# Altium schematic sheet STM32MCUBLUE0.0A.SchDoc(STM32MCUBLUE0.0A)
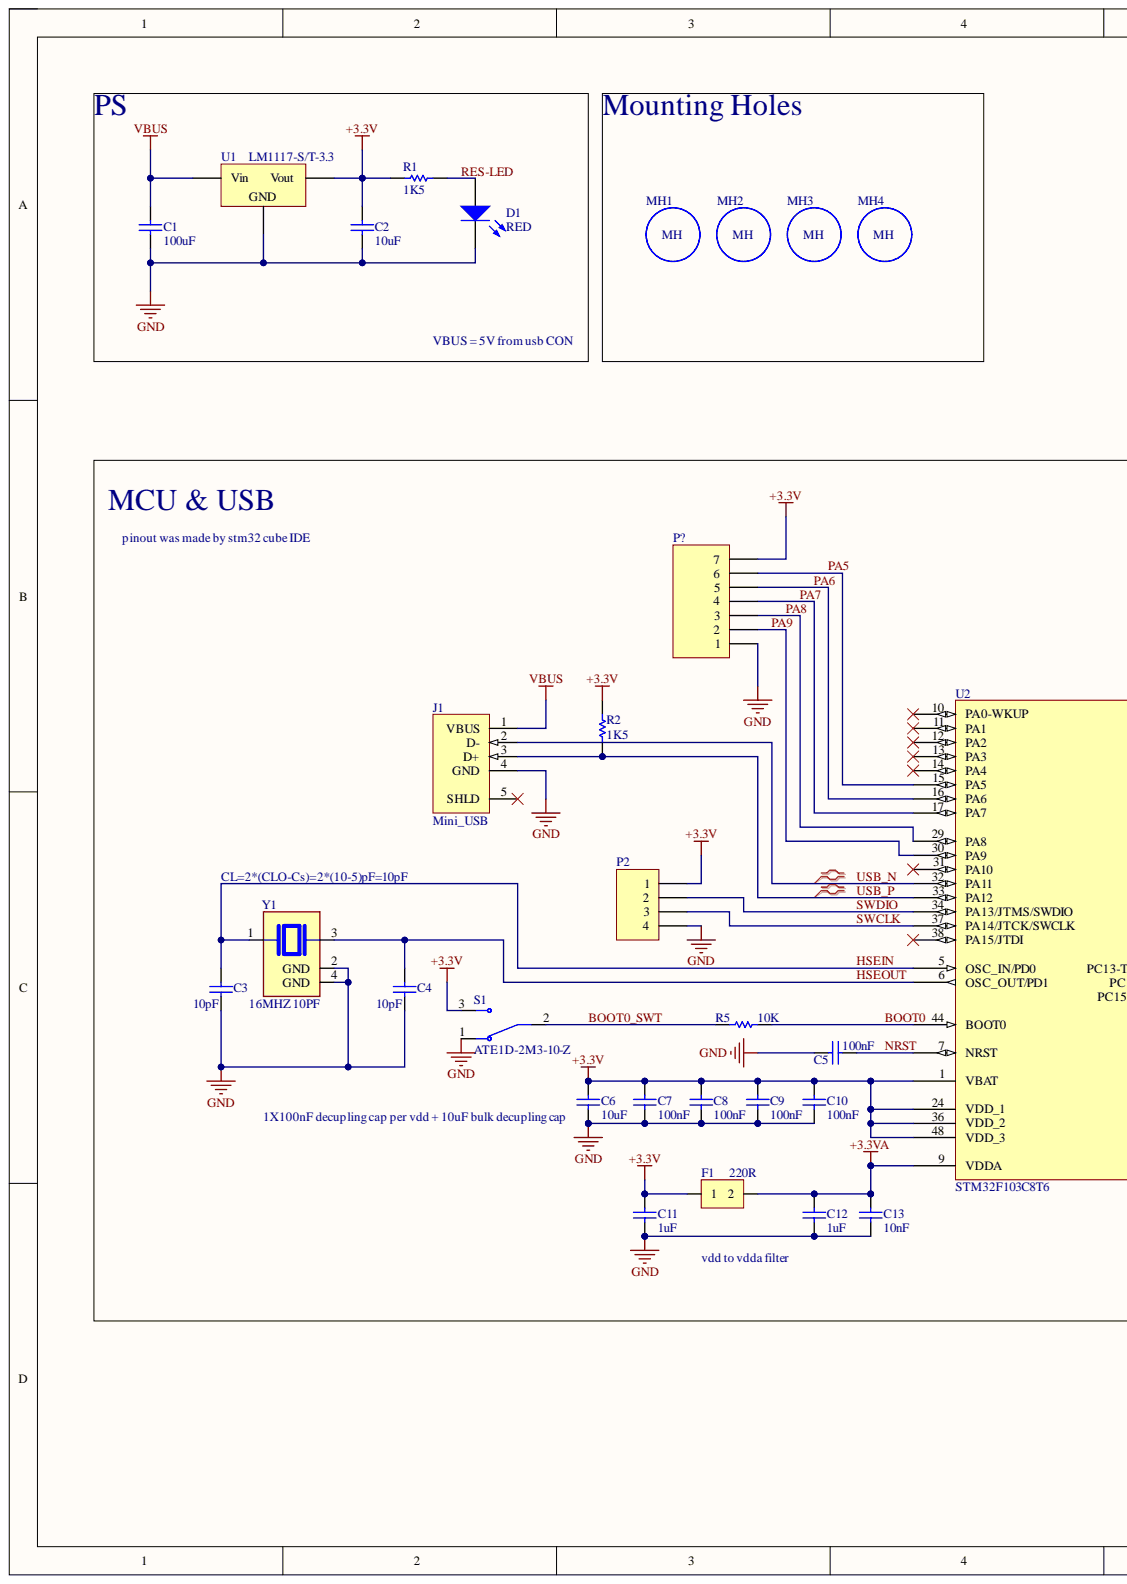
[diagram p0: STM32MCUBLUE0.0A.SchDoc, left]
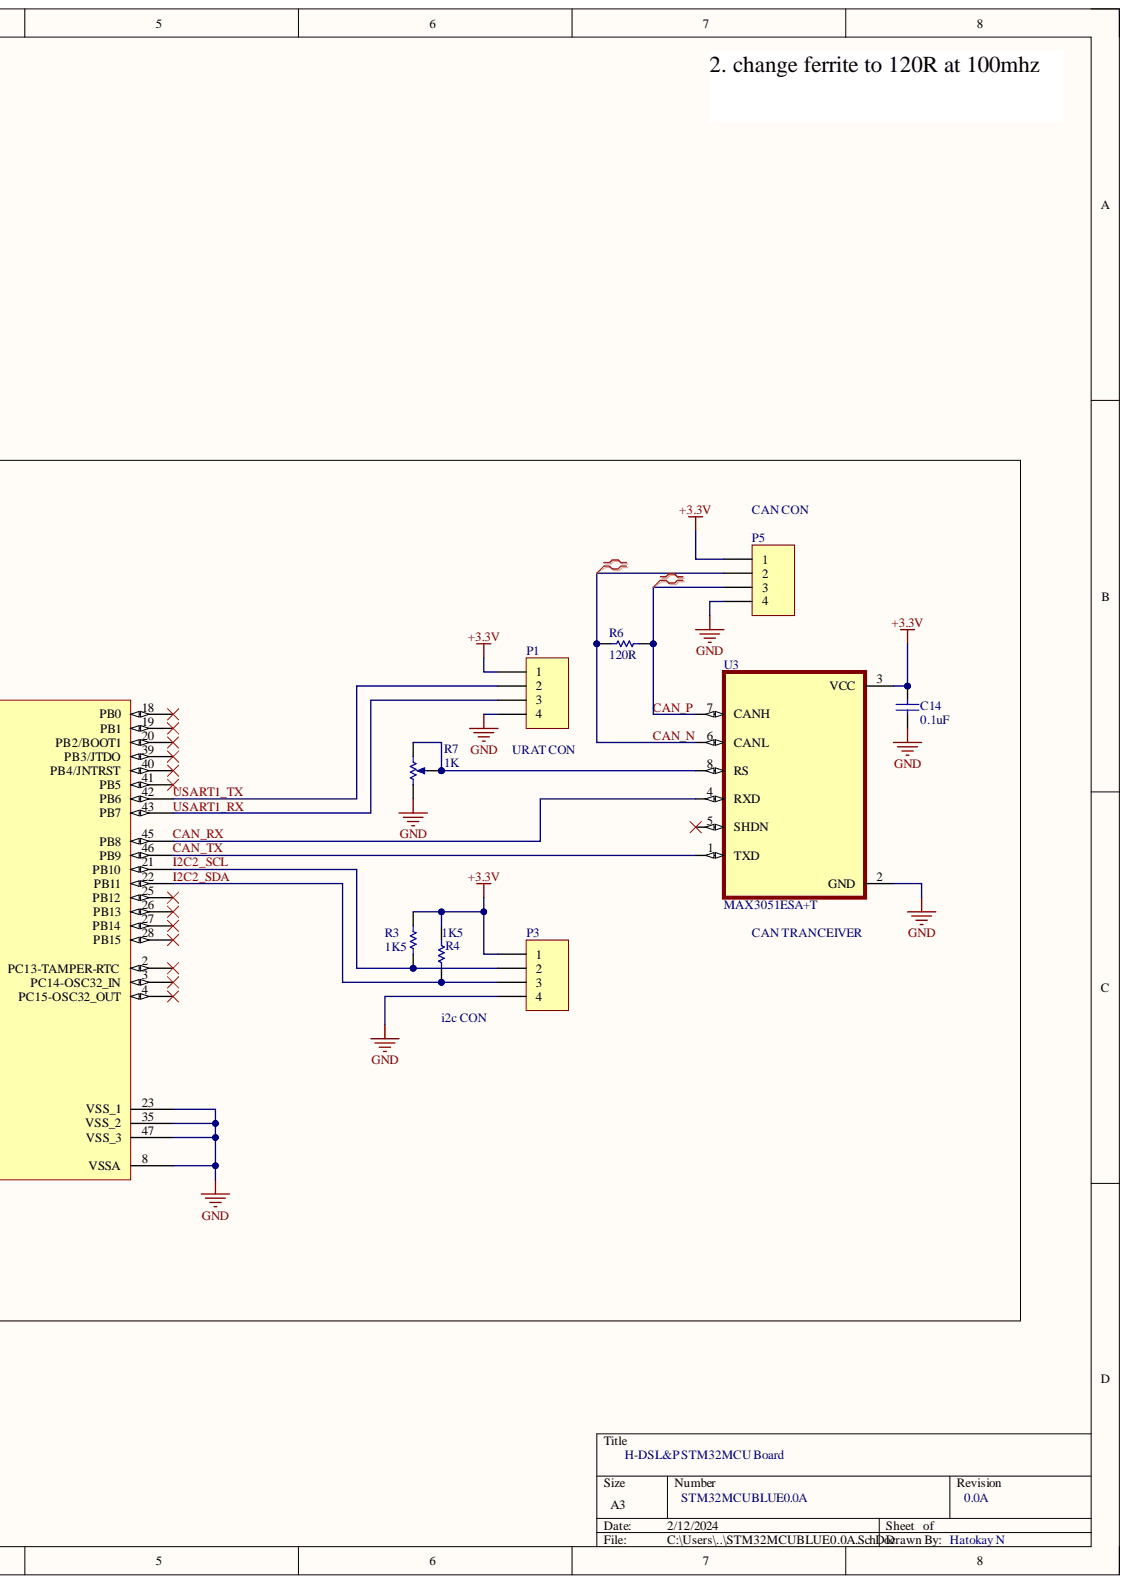
[diagram p1: STM32MCUBLUE0.0A.SchDoc, right]

## Parts
C1  100uF  [MY_0603]
C2  10uF  [MY_0603]
C3  10pF  [MY_0603]
C4  10pF  [MY_0603]
C5  100nF  [MY_0603]
C6  10uF  [MY_0603]
C7  100nF  [MY_0603]
C8  100nF  [MY_0603]
C9  100nF  [MY_0603]
C10  100nF  [MY_0603]
C11  1uF  [MY_0603]
C12  1uF  [MY_0603]
C13  10nF  [MY_0603]
C14  0.1uF  [MY_0603]
D1  RED  [MY_LED_0805]
F1  220R  [0805]
J1  Mini_USB  [My_USB]
MH1  3mm Mounting Hole  [3mm Mouning Hole]  (value not drawn)
MH2  3mm Mounting Hole  [3mm Mouning Hole]  (value not drawn)
MH3  3mm Mounting Hole  [3mm Mouning Hole]  (value not drawn)
MH4  3mm Mounting Hole  [3mm Mouning Hole]  (value not drawn)
P1  Header 4  [My_1X04]  (value not drawn)
P2  Header 4  [My_1X04]  (value not drawn)
P3  Header 4  [My_1X04]  (value not drawn)
P5  Header 4  [My_1X04]  (value not drawn)
P?  Header 7  [MY_FE07]  (value not drawn)
R1  1K5  [MY_0402]
R2  1K5  [MY_0402]
R3  1K5  [MY_0402]
R4  1K5  [MY_0402]
R5  10K  [MY_0402]
R6  120R  [MY_0402]
R7  1K  [My_Pot]
S1  ATE1D-2M3-10-Z  [SW_ATE1D-2M3-10-Z]
U1  LM1117-S/T-3.3  [MY_SOT223]
U2  STM32F103C8T6  [LQFP48_N]
U3  MAX3051ESA+T  [SOIC127P600X175-8N]
Y1  16MHZ 10PF  [XTAL_ABM8-16.000MHZ-10-1-U-T]

## Nets
+3.3V: C2.2 C6.2 C7.2 C8.2 C9.2 C10.2 C11.2 C14.2 F1.1 P1.1 P2.1 P3.1 P5.1 P?.7 R1.2 R2.2 R3.2 R4.2 S1.3 U1.2 U2.1(VBAT) U2.24(VDD_1) U2.36(VDD_2) U2.48(VDD_3) U3.3(VCC)
+3.3VA: C12.2 C13.2 F1.2 U2.9(VDDA)
GND: C1.1 C2.1 C3.1 C4.1 C5.1 C6.1 C7.1 C8.1 C9.1 C10.1 C11.1 C12.1 C13.1 C14.1 D1.K J1.4(GND) P1.4 P2.4 P3.4 P5.4 P?.1 R7.1 S1.1 U1.1 U2.8(VSSA) U2.23(VSS_1) U2.35(VSS_2) U2.47(VSS_3) U3.2(GND) Y1.2(GND) Y1.4(GND)
VBUS: C1.2 J1.1(VBUS) U1.3
BOOT0: R5.2 U2.44(BOOT0)
BOOT0_SWT: R5.1 S1.2
CAN_N: P5.2 R6.2 U3.6(CANL)
CAN_P: P5.3 R6.1 U3.7(CANH)
CAN_RX: U2.45(PB8) U3.4(RXD)
CAN_TX: U2.46(PB9) U3.1(TXD)
HSEIN: C3.2 U2.5(OSC_IN/PD0) Y1.1
HSEOUT: C4.2 U2.6(OSC_OUT/PD1) Y1.3
I2C2_SCL: P3.2 R3.1 U2.21(PB10)
I2C2_SDA: P3.3 R4.1 U2.22(PB11)
NRST: C5.2 U2.7(NRST)
PA5: P?.6 U2.15(PA5)
PA6: P?.5 U2.16(PA6)
PA7: P?.4 U2.17(PA7)
PA8: P?.3 U2.29(PA8)
PA9: P?.2 U2.30(PA9)
RES-LED: D1.A R1.1
SWCLK: P2.3 U2.37(PA14/JTCK/SWCLK)
SWDIO: P2.2 U2.34(PA13/JTMS/SWDIO)
USART1_RX: P1.3 U2.43(PB7)
USART1_TX: P1.2 U2.42(PB6)
USB_N: J1.2(D-) U2.32(PA11)
USB_P: J1.3(D+) R2.1 U2.33(PA12)
NetR7_2: R7.2 R7.3 U3.8(RS)

Not connected: J1 5(SHLD); U2 2(PC13-TAMPER-RTC) 3(PC14-OSC32_IN) 4(PC15-OSC32_OUT) 10(PA0-WKUP) 11(PA1) 12(PA2) 13(PA3) 14(PA4) 18(PB0) 19(PB1) 20(PB2/BOOT1) 25(PB12) 26(PB13) 27(PB14) 28(PB15) 31(PA10) 38(PA15/JTDI) 39(PB3/JTDO) 40(PB4/JNTRST) 41(PB5); U3 5(SHDN)

## Library data of the parts
C1-C14 = Cap ceramic: None polar capacitor; ASiD Part Number: C-AS; Volte: 50v; Component Kind: Standard; Library Name: Mylib+Rev+4 (1).IntLib
  C1: Value: 100uF
  C2 C6: Value: 10uF
  C3 C4: Value: 10pF
  C5 C7-C10: Value: 100nF
  C11 C12: Value: 1uF
  C13: Value: 10nF
  C14: Value: 0.1uF
D1 = LED: Typical INFRARED GaAs LED; ASiD Part Number: LED-AS; Component Kind: Standard; Library Name: Mylib+Rev+4 (1).IntLib
F1 = BLM21PG221SN1D: Component Kind: Standard; Library Name: murata.IntLib
J1 = Mini_USB: USB 2.0, Right Angle, SMT, B Type, Receptacle, 5 Position, Black; ASiD Part Number: Mini_USB-AS; Component Kind: Standard; Library Name: Mylib+Rev+4 (1).IntLib; Signal Integrity: USB2.0
MH1-MH4 = 3mm Mounting Hole: 3mm MH; Component Kind: Standard; Library Name: Noah_LIB.IntLib
P1-P3 P5 = Header 4: Header, 4-Pin; ASiD Part Number: Header 4-AS; Component Kind: Standard; Library Name: Mylib+Rev+4 (1).IntLib; Signal Integrity: Connector
P? = Header 7: Header, 8-Pin, Dual row; ASiD Part Number: Header 7-AS; Component Kind: Standard; Library Name: Mylib+Rev+4 (1).IntLib; Signal Integrity: Connector
R1-R6 = Res: Standard resistor; ASiD Part Number: Res-AS; Component Kind: Standard; Library Name: Mylib+Rev+4 (1).IntLib
  R1-R4: Value: 1K5
  R5: Value: 10K
  R6: Value: 120R
R7 = Variable Resistor: Potentiometer; ASiD Part Number: Variable Resistor-AS; Component Kind: Standard; Library Name: Mylib+Rev+4 (1).IntLib; Value: 1K
S1 = ATE1D-2M3-10-Z: Toggle Switch SPDT Through Hole; MF: Nidec Copal Electronics; Check_prices: https://www.snapeda.com/parts/ATE1D-2M3-10-Z/Nidec+Copal+Electronics/view-part/?ref=eda; Component Kind: Standard; Digi-Key_Part_Number: 563-1157-ND; Library Name: ATE1D-2M3-10-Z.IntLib; MP: ATE1D-2M3-10-Z; Package: None; Purchase-URL: https://pricing.snapeda.com/search/part/ATE1D-2M3-10-Z/?ref=eda; SnapEDA_Link: https://www.snapeda.com/parts/ATE1D-2M3-10-Z/Nidec+Copal+Electronics/view-part/?ref=snap
U1 = LM1117: Low Drop Voltage Reg; ASiD Part Number: LM1117-AS; Component Kind: Standard; Library Name: Mylib+Rev+4 (1).IntLib
U2 = STM32F103C8T6: STM32 ARM-based 32-bit MCU with 64 Kbytes Flash, 48-pin LQFP, Industrial Temperature; Component Kind: Standard; ComponentLink1Description: Manufacturer Link; ComponentLink1URL: www.st.com/stm32; ComponentLink2Description: Datasheet; ComponentLink2URL: http://www.st.com/stonline/products/literature/ds/13587.pdf; DatasheetVersion: rev6, Mar-2008; Library Name: ST Microcontroller 32-Bit STM32.IntLib; PackageDescription: 48-Pin Low-Profile Quad Flat Pack, 7 x 7 mm Body, 0.5 mm Pitch (LQFP48); PackageReference: LQFP48; PackageVersion: Mar-2008; Published: 30-May-2008; Publisher: Altium Limited
U3 = MAX3051ESA+T: CAN 1Mbps Shutdown/Standby 3.3V 8-Pin SOIC N T/R; MF: Maxim Integrated Products; Check_prices: https://www.snapeda.com/parts/MAX3051ESA+T/Analog+Devices/view-part/?ref=eda; Component Kind: Standard; Digi-Key_Part_Number: MAX3051ESA+TR-ND; Library Name: MAX3051ESA_T.IntLib; MP: MAX3051ESA+T; Package: None; SnapEDA_Link: https://www.snapeda.com/parts/MAX3051ESA+T/Analog+Devices/view-part/?ref=snap
Y1 = ABM8-16.000MHZ-10-1-U-T: Availability: Unavailable; Component Kind: Standard; Library Name: ABM8-16.000MHZ-10-1-U-T.IntLib; MP: ABM8-16.000MHZ-10-1-U-T; Package: None; Price: None
